# Altium schematic sheet nRF53.SchDoc(nRF53)
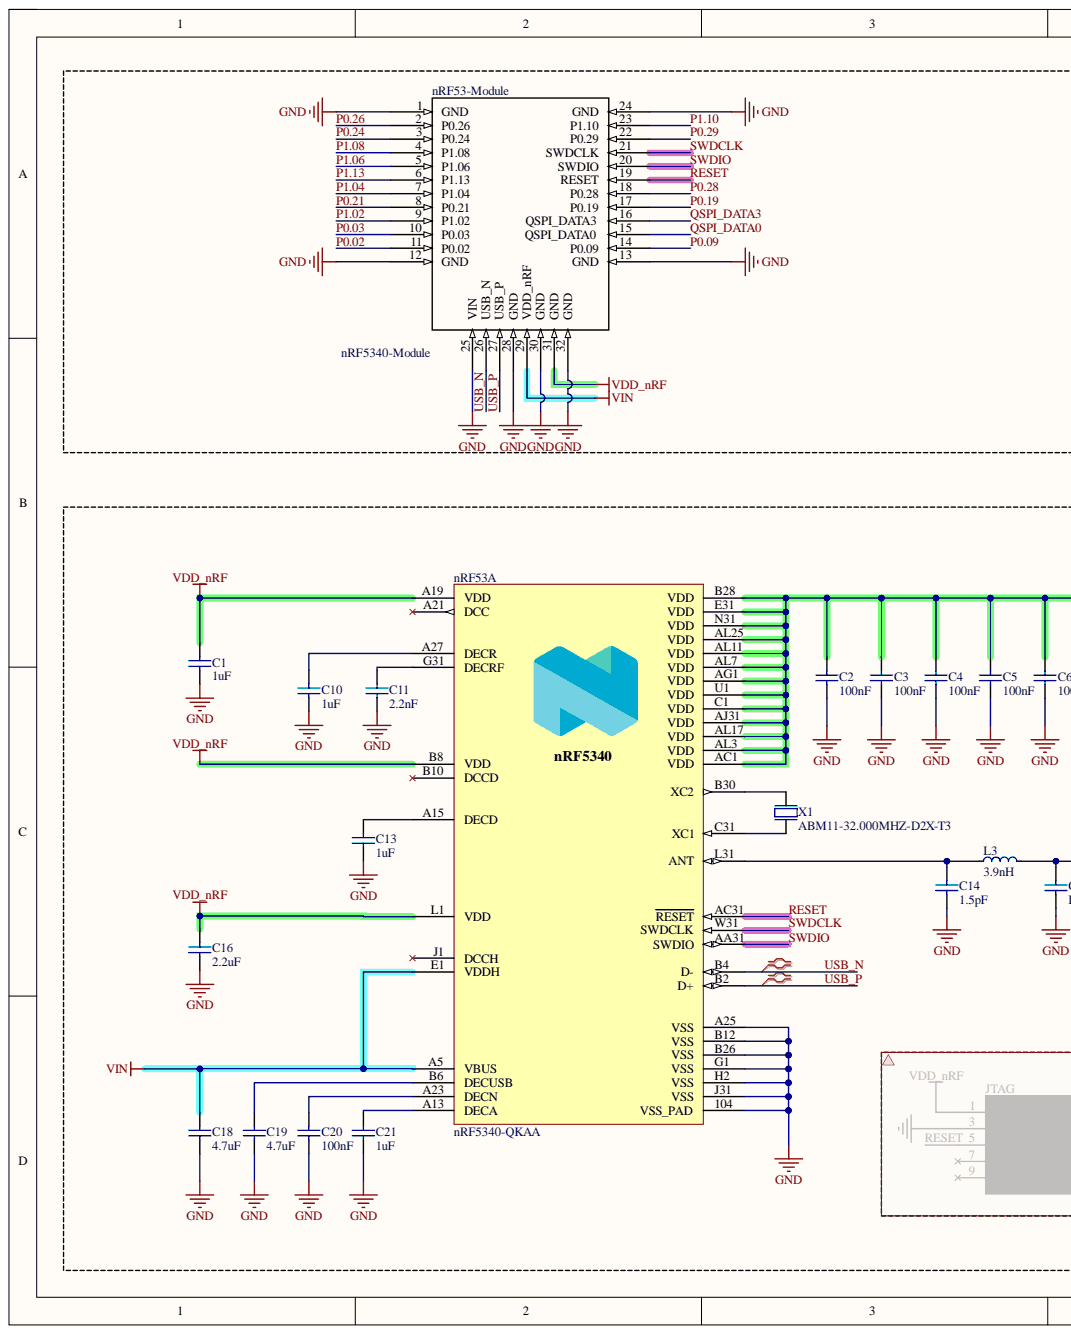
[diagram p0: nRF53.SchDoc, left]
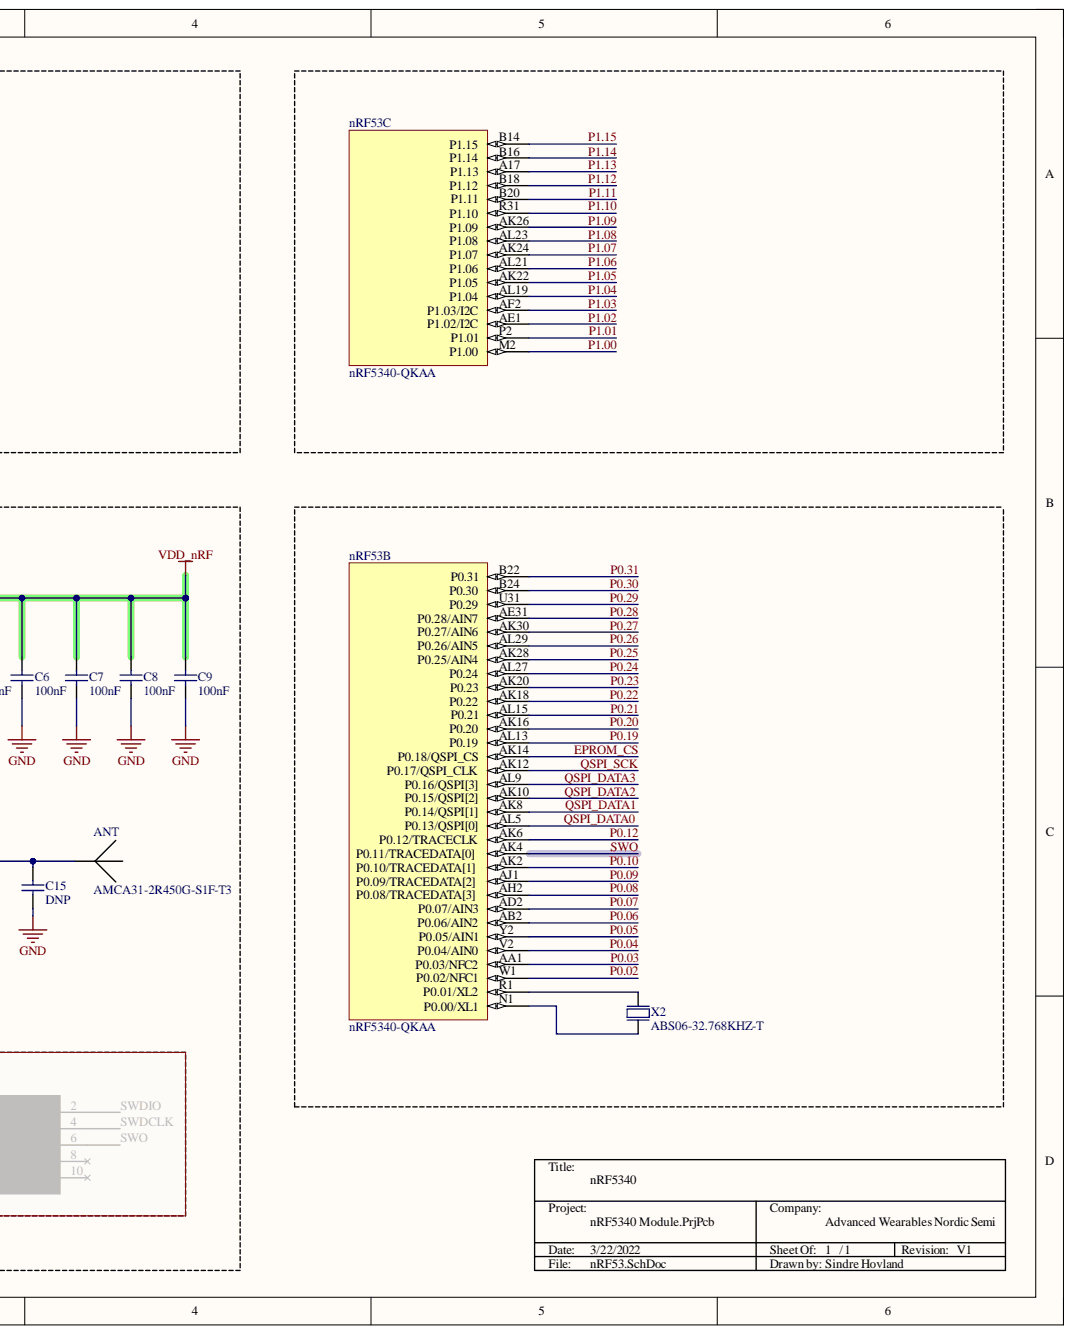
[diagram p1: nRF53.SchDoc, right]

## Parts
ANT  AMCA31-2R450G-S1F-T3  [AMCA31-2R450G-S1F-T3]
C1  1uF  [C0201]
C2  100nF  [C0201]
C3  100nF  [C0201]
C4  100nF  [C0201]
C5  100nF  [C0201]
C6  100nF  [C0201]
C7  100nF  [C0201]
C8  100nF  [C0201]
C9  100nF  [C0201]
C10  1uF  [C0201]
C11  2.2nF  [C0201]
C13  1uF  [C0201]
C14  1.5pF  [C0201]
C15  DNP  [C0201]
C16  2.2uF  [C0201]
C18  4.7uF  [C0402]
C19  4.7uF  [C0402]
C20  100nF  [C0201]
C21  1uF  [C0201]
L3  3.9nH  [IND0201]
nRF53  nRF5340-QKAA  [nRF5340]  (parts A,B,C)
nRF53-Module  nRF5340-Module  [nRF53Module]
X1  ABM11-32.000MHZ-D2X-T3  [XTAL_2016]
X2  ABS06-32.768KHZ-T  [XTAL_2012]

## Nets
GND: ANT.2 C1.1 C2.1 C3.1 C4.1 C5.1 C6.1 C7.1 C8.1 C9.1 C10.1 C11.1 C13.1 C14.1 C15.1 C16.1 C18.1 C19.1 C20.1 C21.1 nRF53.104(VSS_PAD) nRF53.A25(VSS) nRF53.B12(VSS) nRF53.B26(VSS) nRF53.G1(VSS) nRF53.H2(VSS) nRF53.J31(VSS) nRF53-Module.1(GND) nRF53-Module.12(GND) nRF53-Module.13(GND) nRF53-Module.24(GND) nRF53-Module.25(VIN) nRF53-Module.28(GND) nRF53-Module.30(GND) nRF53-Module.32(GND) X1.2 X1.4
VDD_nRF: C1.2 C2.2 C3.2 C4.2 C5.2 C6.2 C7.2 C8.2 C9.2 C16.2 nRF53.A19(VDD) nRF53.AC1(VDD) nRF53.AG1(VDD) nRF53.AJ31(VDD) nRF53.AL3(VDD) nRF53.AL7(VDD) nRF53.AL11(VDD) nRF53.AL17(VDD) nRF53.AL25(VDD) nRF53.B8(VDD) nRF53.B28(VDD) nRF53.C1(VDD) nRF53.E31(VDD) nRF53.L1(VDD) nRF53.N31(VDD) nRF53.U1(VDD) nRF53-Module.31(GND)
VIN: C18.2 nRF53.A5(VBUS) nRF53.E1(VDDH) nRF53-Module.29(VDD_nRF)
EPROM_CS: nRF53.AK14(P0.18/QSPI_CS)
P0.02: nRF53.W1(P0.02/NFC1) nRF53-Module.11(P0.02)
P0.03: nRF53.AA1(P0.03/NFC2) nRF53-Module.10(P0.03)
P0.04: nRF53.V2(P0.04/AIN0)
P0.05: nRF53.Y2(P0.05/AIN1)
P0.06: nRF53.AB2(P0.06/AIN2)
P0.07: nRF53.AD2(P0.07/AIN3)
P0.08: nRF53.AH2(P0.08/TRACEDATA[3])
P0.09: nRF53.AJ1(P0.09/TRACEDATA[2]) nRF53-Module.14(P0.09)
P0.10: nRF53.AK2(P0.10/TRACEDATA[1])
P0.12: nRF53.AK6(P0.12/TRACECLK)
P0.19: nRF53.AL13(P0.19) nRF53-Module.17(P0.19)
P0.20: nRF53.AK16(P0.20)
P0.21: nRF53.AL15(P0.21) nRF53-Module.8(P0.21)
P0.22: nRF53.AK18(P0.22)
P0.23: nRF53.AK20(P0.23)
P0.24: nRF53.AL27(P0.24) nRF53-Module.3(P0.24)
P0.25: nRF53.AK28(P0.25/AIN4)
P0.26: nRF53.AL29(P0.26/AIN5) nRF53-Module.2(P0.26)
P0.27: nRF53.AK30(P0.27/AIN6)
P0.28: nRF53.AE31(P0.28/AIN7) nRF53-Module.18(P0.28)
P0.29: nRF53.U31(P0.29) nRF53-Module.22(P0.29)
P0.30: nRF53.B24(P0.30)
P0.31: nRF53.B22(P0.31)
P1.00: nRF53.M2(P1.00)
P1.01: nRF53.P2(P1.01)
P1.02: nRF53.AE1(P1.02/I2C) nRF53-Module.9(P1.02)
P1.03: nRF53.AF2(P1.03/I2C)
P1.04: nRF53.AL19(P1.04) nRF53-Module.7(P1.04)
P1.05: nRF53.AK22(P1.05)
P1.06: nRF53.AL21(P1.06) nRF53-Module.5(P1.06)
P1.07: nRF53.AK24(P1.07)
P1.08: nRF53.AL23(P1.08) nRF53-Module.4(P1.08)
P1.09: nRF53.AK26(P1.09)
P1.10: nRF53.R31(P1.10) nRF53-Module.23(P1.10)
P1.11: nRF53.B20(P1.11)
P1.12: nRF53.B18(P1.12)
P1.13: nRF53.A17(P1.13) nRF53-Module.6(P1.13)
P1.14: nRF53.B16(P1.14)
P1.15: nRF53.B14(P1.15)
QSPI_DATA0: nRF53.AL5(P0.13/QSPI[0]) nRF53-Module.15(QSPI_DATA0)
QSPI_DATA1: nRF53.AK8(P0.14/QSPI[1])
QSPI_DATA2: nRF53.AK10(P0.15/QSPI[2])
QSPI_DATA3: nRF53.AL9(P0.16/QSPI[3]) nRF53-Module.16(QSPI_DATA3)
QSPI_SCK: nRF53.AK12(P0.17/QSPI_CLK)
RESET: nRF53.AC31(RESET) nRF53-Module.19(RESET)
SWDCLK: nRF53.W31(SWDCLK) nRF53-Module.21(SWDCLK)
SWDIO: nRF53.AA31(SWDIO) nRF53-Module.20(SWDIO)
SWO: nRF53.AK4(P0.11/TRACEDATA[0])
USB_N: nRF53.B4(D-) nRF53-Module.26(USB_N)
USB_P: nRF53.B2(D+) nRF53-Module.27(USB_P)
NetANT_1: ANT.1 C15.2 L3.2
NetC10_2: C10.2 nRF53.A27(DECR)
NetC11_2: C11.2 nRF53.G31(DECRF)
NetC13_2: C13.2 nRF53.A15(DECD)
NetC14_2: C14.2 L3.1 nRF53.L31(ANT)
NetC19_2: C19.2 nRF53.B6(DECUSB)
NetC20_2: C20.2 nRF53.A23(DECN)
NetC21_2: C21.2 nRF53.A13(DECA)
NetnRF53_B30: nRF53.B30(XC2) X1.3
NetnRF53_C31: nRF53.C31(XC1) X1.1
NetnRF53_N1: nRF53.N1(P0.00/XL1) X2.2
NetnRF53_R1: nRF53.R1(P0.01/XL2) X2.1

Not connected: nRF53 A21(DCC) B10(DCCD) J1(DCCH)

## Library data of the parts
ANT = Antenna with GND: 2.45 GHz chip antenna; Manufacturer: Johanson Technology; Manufacturer Part Number: 2450AT18D0100; Part Number: Johanson 2450AT18D0100_STD-L; Part number IPS: N.A.; Artikkelnr Noca: 53059035; Value: 2450AT18D0100; Component Kind: Standard; ComponentLink1Description: Datasheet; ComponentLink2Description: Supplier link; Footprint Doc: 2450AT18D0100; HelpURL: http://www.johansontechnology.com/datasheets/antennas/2450AT18D0100.pdf; LatestRevisionDate: 2016-06-02; Library Name: Passives.SchLib; Pin Count: 4; Published: 2016-01-12; Supplier 1: Digi-Key; Supplier 2: Mouser; Supplier Part Number 1: 2450AT18D0100E; Supplier Part Number 2: 609-2450AT18D0100E; Publisher: Nordic Semiconductor
C1-C11 C13-C16 C18-C21 = CAP: Capacitor; Value: 100pF; Component Kind: Standard; Library Name: Passives.SchLib; Published: 8-Jun-2000; Revision: July-2002: Re-released for DXP Platform.; Publisher: Altium Limited
L3 = IND: Inductor; Manufacturer: Murata; Manufacturer Part Number: BLM15AG121SN1D; Part Number: FB0402-120R@100M-500mA; Part number IPS: N.A.; Artikkelnr Noca: 33029317; Note: Chip EMIFIL Inductor Type Chip Ferrite Bead; Value: 120R/0.55A; Component Kind: Standard; ComponentLink1Description: Datasheet; ComponentLink2Description: Supplier link; Footprint Doc: 0402; HelpURL: http://search.murata.co.jp/Ceramy/image/img/PDF/ENG/L0110S0100BLM15A_SN.pdf; LatestRevisionDate: 2014-01-10; Library Name: Passives.SchLib; Pin Count: 2; Published: 2011-11-14; Publisher: Nordic Semiconductor
nRF53 = nRF5340-QKAAAA: Multi-protocol Bluetooth Low Energy, IEEE 802.15.4, ANT and 2.4GHz proprietary system-on-chip; Manufacturer: Nordic Semiconductor; Part Number: nRF5340-QKAADA; Artikkelnr Noca: N.A.; Component Kind: Standard; ComponentLink1Description: Datasheet; ComponentLink2Description: Supplier link; Footprint Doc: AQFN-98; LatestRevisionDate: 2019-03-28; Library Name: MCU.SchLib; Part number IPS: N.A.; Pin Count: 98; Published: 2017-01-09; Supplier 1: Nordic Semiconductor; Supplier Part Number 1: nRF5340-QKAADA; Value: nRF5340-QKAA; Publisher: Nordic Semiconductor
nRF53-Module = nRF5340-Module: nRF5340-Module Available on https://www.hackster.io/kattemjau; Component Kind: Standard; Library Name: MCU.SchLib
X1 = XTAL_SMD2016: XTAL SMD 2016, 32MHz, Cl=8pF, Total Tol: ±40ppm; Part Number: XTAL_SMD2016_32MHz_8pF_General; Component Kind: Standard; Footprint Doc: XTAL_2016; LatestRevisionDate: 2016-08-19; Library Name: Passives.SchLib; Pin Count: 4; Published: 2016-08-19; Value: 32MHz; Publisher: Nordic Semiconductor
X2 = XTAL_2-pin: XTAL SMD 32.768kHz, 9pF, ±20ppm; Manufacturer: NDK; Manufacturer Part Number: NX3215SA-32.768K-STD-MUA-9; Part Number: XTAL_SMD3215_32.768kHz_9pF_NDK; Part number IPS: N.A.; Artikkelnr Noca: 22010049; Component Kind: Standard; ComponentLink1Description: Datasheet; ComponentLink2Description: Supplier link; Footprint Doc: XTAL_3215; LatestRevisionDate: 2017-10-23; Library Name: Passives.SchLib; Note: XTAL SMD 32.768kHz; Pin Count: 2; Published: 2017-10-23; Supplier 1: Digi-Key; Supplier Part Number 1: 535-11937-6-ND; Value: 32.768kHz; Publisher: Nordic Semiconductor
